# Altium schematic sheet [04]Power Supplies.SchDoc
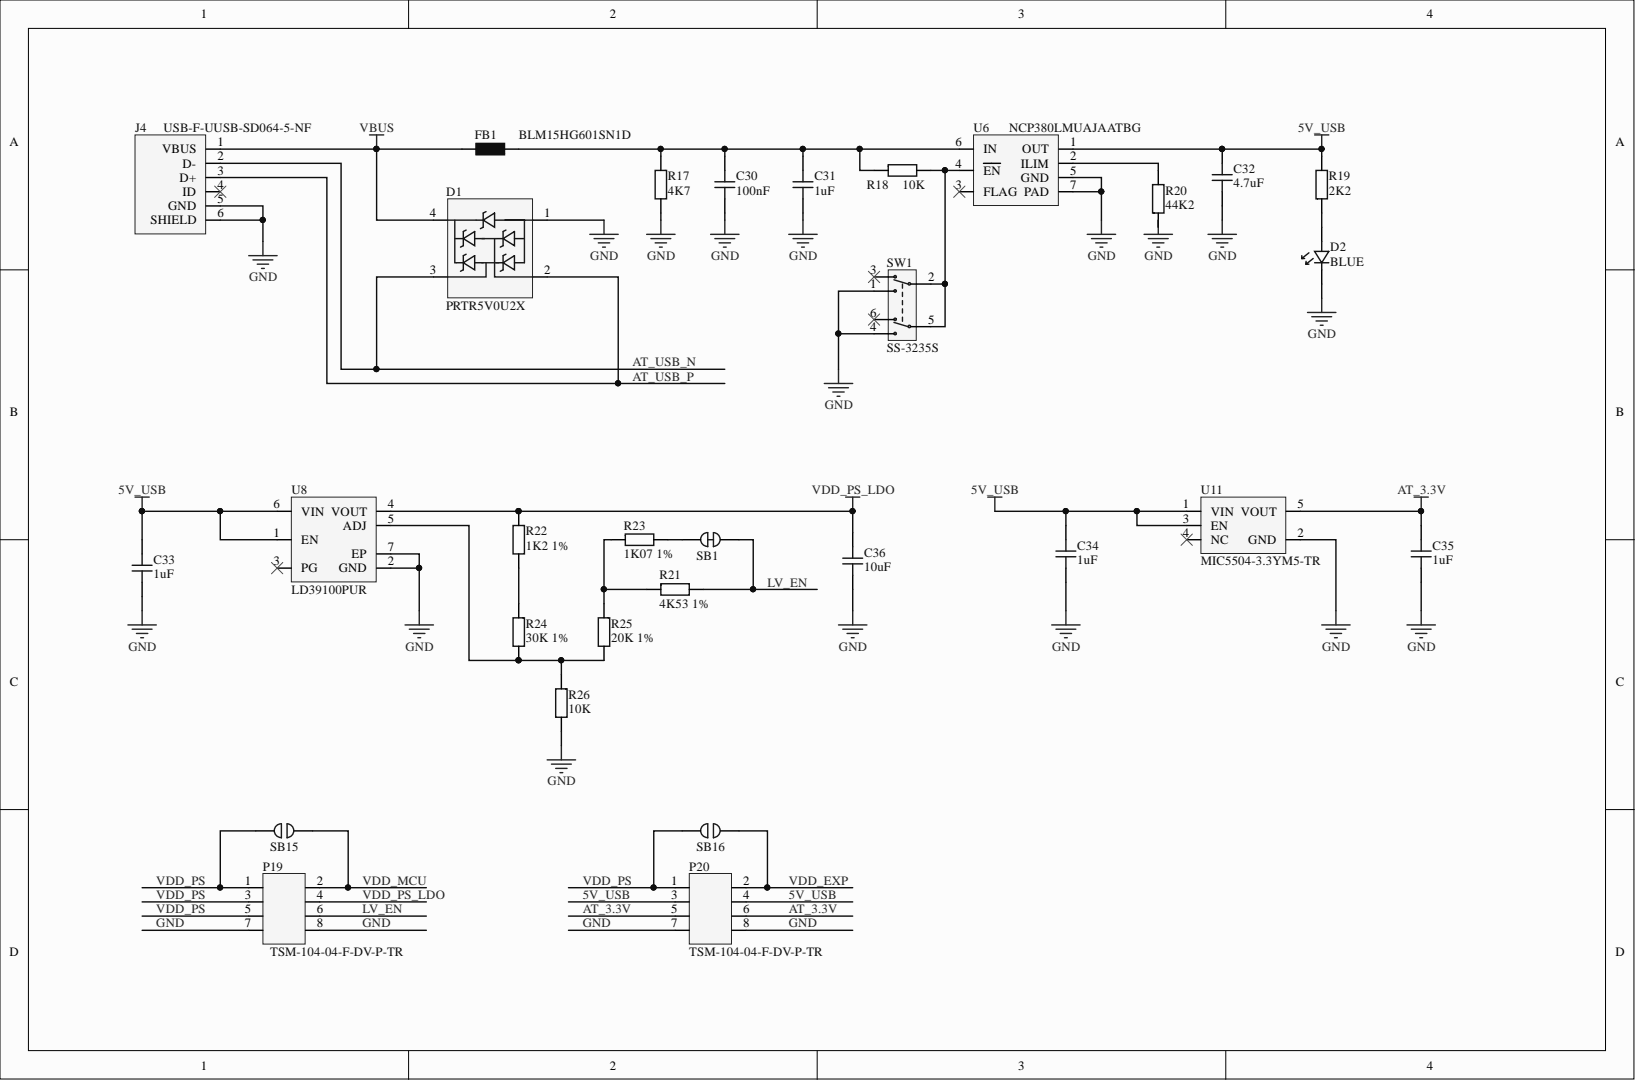
[diagram p0: [04]Power Supplies.SchDoc]

## Parts
C30  100nF  [CAPC1005L]
C31  1uF  [CAPC1005L]
C32  4.7uF  [CAPC1005L]
C33  1uF  [CAPC1005L]
C34  1uF  [CAPC1005L]
C35  1uF  [CAPC1005L]
C36  10uF  [CAPC1608N]
D1  PRTR5V0U2X  [SOT143L]
D2  BLUE  [LEDC1608L]
FB1  BLM15HG601SN1D  [FBDC1005L]
J4  USB-F-UUSB-SD064-5-NF  [10118193-0001LF]
P19  TSM-104-04-F-DV-P-TR  [TSM-104-04-F-DV-TR]
P20  TSM-104-04-F-DV-P-TR  [TSM-104-04-F-DV-TR]
R17  4K7  [RESC1005L]
R18  10K  [RESC1005L]
R19  2K2  [RESC1005L]
R20  44K2  [RESC1005L]
R21  4K53 1%  [RESC1005L]
R22  1K2 1%  [RESC1005L]
R23  1K07 1%  [RESC1005L]
R24  30K 1%  [RESC1005L]
R25  20K 1%  [RESC1005L]
R26  10K  [RESC1005L]
SB1  SBS  [SBS]  (value not drawn)
SB15  SBO  [SBO]  (value not drawn)  (no BOM)
SB16  SBO  [SBO]  (value not drawn)  (no BOM)
SW1  SS-3235S  [JS202011SCQN]
U6  NCP380LMUAJAATBG  [UDFN-6]
U8  LD39100PUR  [DFN6]
U11  MIC5504-3.3YM5-TR  [SOT23-5_L]

## Nets
VBUS: D1.4 FB1.1 J4.1(VBUS)
5V_USB: C32.2 C33.2 C34.2 P20.3 P20.4 R19.1 U6.1(OUT) U8.1(EN) U8.6(VIN) U11.1(VIN) U11.3(EN)
AT_3.3V: C35.2 P20.5 P20.6 U11.5(VOUT)
AT_USB_N: D1.3 J4.2(D-)
AT_USB_P: D1.2 J4.3(D+)
GND: C30.1 C31.1 C32.1 C33.1 C34.1 C35.1 C36.1 D1.1 D2.1 J4.5(GND) J4.6(SHIELD) P19.7 P19.8 P20.7 P20.8 R17.1 R20.2 R26.1 SW1.1 SW1.4 U6.5(GND) U6.7(PAD) U8.2(GND) U8.7(EP) U11.2(GND)
LV_EN: P19.6 R21.1 SB1.2
VDD_EXP: P20.2 SB16.2
VDD_MCU: P19.2 SB15.2
VDD_PS: P19.1 P19.3 P19.5 P20.1 SB15.1 SB16.1
VDD_PS_LDO: C36.2 P19.4 R22.2 U8.4(VOUT)
NetC30_2: C30.2 C31.2 FB1.2 R17.2 R18.2 U6.6(IN)
NetD2_2: D2.2 R19.2
NetR18_1: R18.1 SW1.2 SW1.5 U6.4(EN)
NetR20_1: R20.1 U6.2(ILIM)
NetR21_2: R21.2 R23.2 R25.2
NetR22_1: R22.1 R24.2
NetR23_1: R23.1 SB1.1
NetR24_1: R24.1 R25.1 R26.2 U8.5(ADJ)

Not connected: J4 4(ID); SW1 3 6; U6 3(FLAG); U8 3(PG); U11 4(NC)

## Library data of the parts
C30-C36 = CAP_CHP: Surface Mount Unpolarized Capacitor; Component Kind: Standard; Library Name: Discrete.SchLib
D1 = PRTR5V0U2X: Ultra low capacitance double rail-to-rail ESD protection diode; Component Kind: Standard; Library Name: Discrete.SchLib
D2 = LED_SMD: Surface Mount LED; Component Kind: Standard; Library Name: Discrete.SchLib
FB1 = FBD_CHP: Surface Mount Ferrite Bead; Component Kind: Standard; Library Name: Discrete.SchLib
J4 = USB-F-UUSB-SD064-5-NF: Micro B USB 2.0 Receptacle Connector 5P SMD, Right Angle, Horizontal; Component Kind: Standard; Library Name: Connector.SchLib
P19 P20 = TSM-104-04-F-DV-P-TR: 8 Positions Header, 2 Row,Gold, Unshrouded Connector 2.54mm SMD; Component Kind: Standard; Library Name: Connector.SchLib
R17-R26 = RES_CHP: Surface Mount Resistor; Component Kind: Standard; Library Name: Discrete.SchLib
SB1 = SBS: Short-circuit soldering bridge; Component Kind: Net Tie; Library Name: Connector.SchLib
SB15 SB16 = SBO: Open-circuit soldering bridge; Library Name: Connector.SchLib
SW1 = SS-3235S: Slide Switch DPDT Surface Mount 9x3.5x3.5  Pitch=2.5mm; Component Kind: Standard; Library Name: Discrete.SchLib
U6 = NCP380: IC CURRENT LIMIT SWITCH UDFN6; Component Kind: Standard; Library Name: IC.SchLib
U8 = LD39100PUR: Voltage Regulator, 1A, Low Quiescent Current  ,DFN6 Tape&Reel; Component Kind: Standard; Library Name: IC.SchLib
U11 = MIC5504-3.3YM5-TR: Linear Voltage Regulator Positive Fixed 1 Output 3.3V 300mA SOT-23-5; Component Kind: Standard; Library Name: IC.SchLib
